# Altium schematic sheet DC_DC.SchDoc
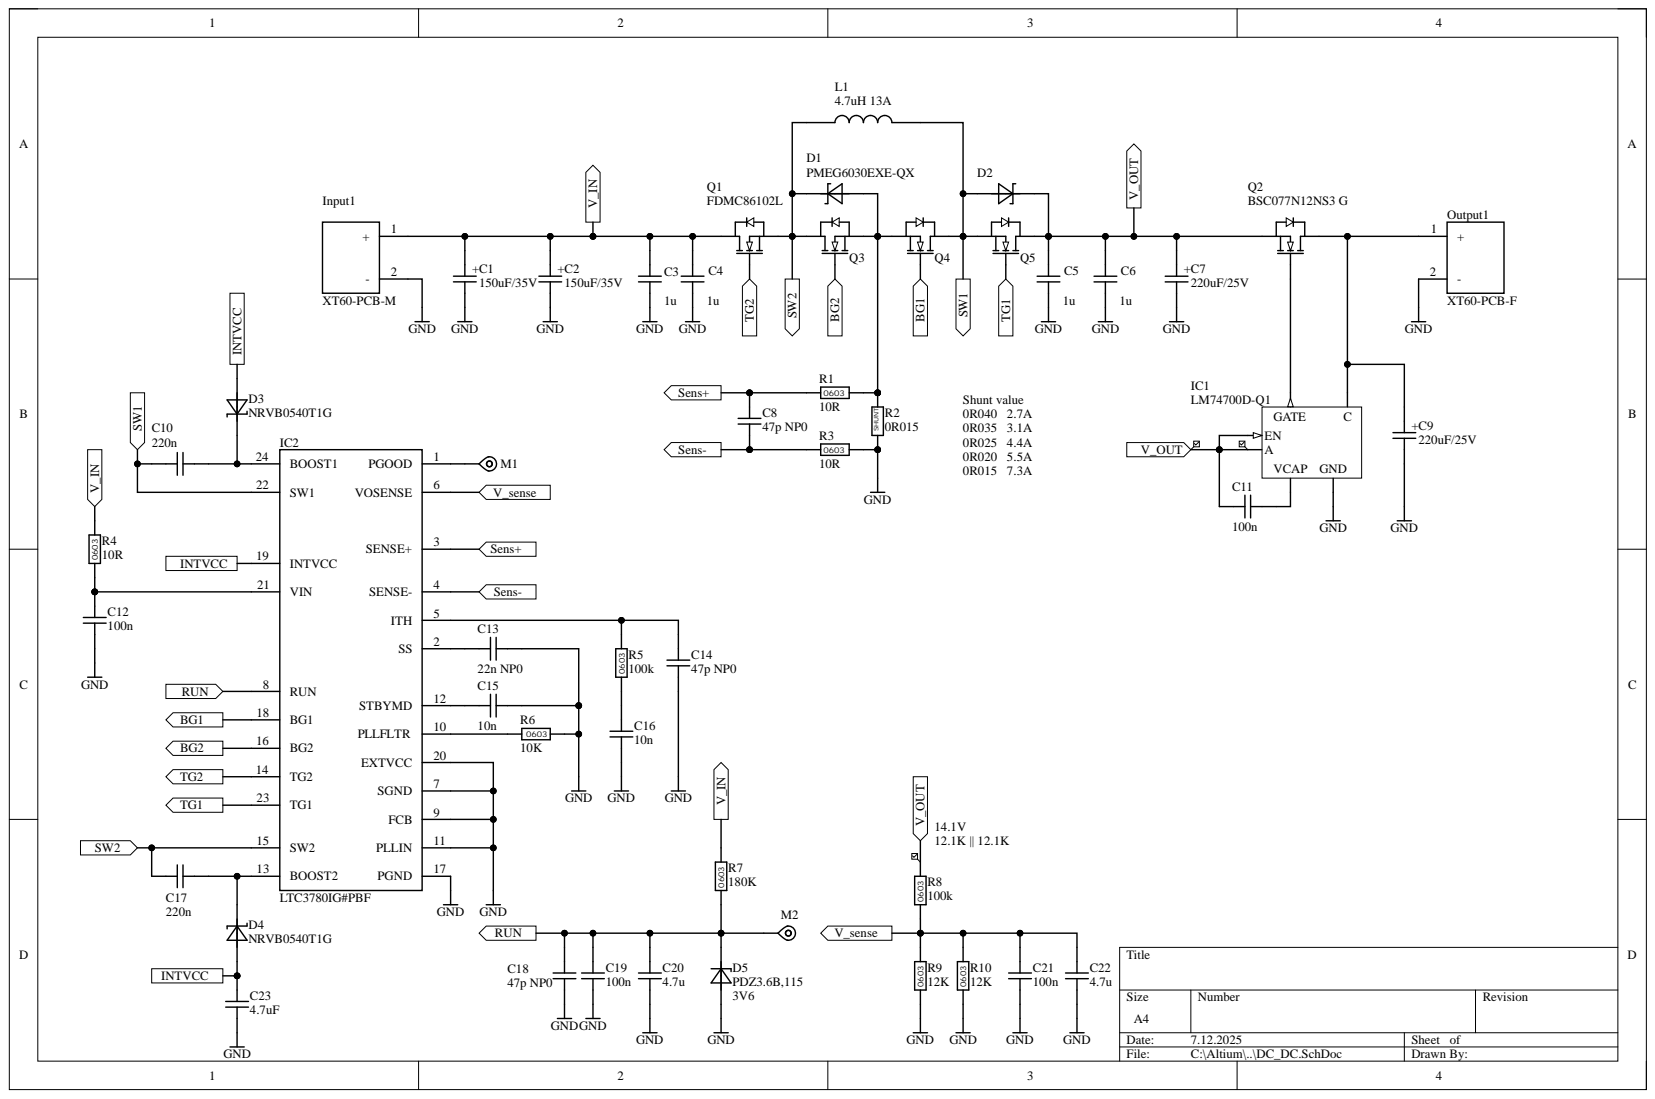
[diagram p0: DC_DC.SchDoc]

## Parts
C1  value 150uF/35V  [PZF8x9]  (hidden comment: 35PZE150M8X9)
C2  value 150uF/35V  [PZF8x9]  (hidden comment: 35PZE150M8X9)
C3  value 1u  [C1206_medium]  (hidden comment: C1206C105K5RACTU)
C4  value 1u  [C1206_medium]  (hidden comment: C1206C105K5RACTU)
C5  value 1u  [C1206_medium]  (hidden comment: C1206C105K5RACTU)
C6  value 1u  [C1206_medium]  (hidden comment: C1206C105K5RACTU)
C7  value 220uF/25V  [PZF8x9]  (hidden comment: 25PZF220M8X9)
C8  value 47p NP0  [C0603]  (hidden comment: GCM1885C2A470JA16J)
C9  value 220uF/25V  [PZF8x9]  (hidden comment: 25PZF220M8X9)
C10  value 220n  [C1206_thin]  (hidden comment: C1206C224J1RACAUTO)
C11  value 100n  [C0603]  (hidden comment: 06035C104K4Z2A)
C12  value 100n  [C1206_thin]  (hidden comment: GRT31C5C2A104JA12L)
C13  value 22n NP0  [C0603]  (hidden comment: AC0603KRX7R9BB223)
C14  value 47p NP0  [C0603]  (hidden comment: GCM1885C2A470JA16J)
C15  value 10n  [C0603]  (hidden comment: C0603X103K1RAC3316)
C16  value 10n  [C0603]  (hidden comment: C0603X103K1RAC3316)
C17  value 220n  [C1206_thin]  (hidden comment: C1206C224J1RACAUTO)
C18  value 47p NP0  [C0603]  (hidden comment: GCM1885C2A470JA16J)
C19  value 100n  [C0603]  (hidden comment: 06035C104K4Z2A)
C20  value 4.7u  [C0603]  (hidden comment: CL10B475KQ8NQNC)
C21  value 100n  [C0603]  (hidden comment: 06035C104K4Z2A)
C22  value 4.7u  [C0603]  (hidden comment: CL10B475KQ8NQNC)
C23  value 4.7uF  [C1206_thick]  (hidden comment: GRM31CC72A475ME11L)
D1  PMEG6030EXE-QX  [SOD123HP]
D2  PMEG6030EXE-QX  [SOD123HP]
D3  NRVB0540T1G  [SOD123HP]
D4  NRVB0540T1G  [SOD123HP]
D5  PDZ3.6B,115  value 3V6  [SOD323]
IC1  LM74700D-Q1  [SOIC127P600X175-8N]
IC2  LTC3780IG#PBF  [SOP65P780X200-24N]
Input1  XT60-PCB-M  [XT60-PCB-M]
L1  value 4.7uH 13A  [WE-HCI]  (hidden comment: 7443551470)
M1  TP  [Pad 1mm SMD]  (value not drawn)  (no BOM)
M2  TP  [Pad 1mm SMD]  (value not drawn)  (no BOM)
Output1  XT60-PCB-F  [XT60-PCB-F]
Q1  FDMC86102L  [PowerPak1212_LFPAK33]
Q2  BSC077N12NS3 G  [Power56_LFPAK]
Q3  FDMC86102L  [PowerPak1212_LFPAK33]
Q4  FDMC86102L  [PowerPak1212_LFPAK33]
Q5  FDMC86102L  [PowerPak1212_LFPAK33]
R1  value 10R  [R0603]  (hidden comment: CR0603-FX-10R0ELF)
R2  value 0R015  [Shunt_2512]  (hidden comment: GMR100HTBFER015)
R3  value 10R  [R0603]  (hidden comment: CR0603-FX-10R0ELF)
R4  value 10R  [R0603]  (hidden comment: CR0603-FX-10R0ELF)
R5  value 100k  [R0603]  (hidden comment: RC0603FR-07100KL)
R6  value 10K  [R0603]  (hidden comment: CRGP0603F10K)
R7  value 180K  [R0603]  (hidden comment: CRGCQ0603F180K)
R8  value 100k  [R0603]  (hidden comment: RC0603FR-07100KL)
R9  value 12K  [R0603]  (hidden comment: CRGCQ0603F12K)
R10  value 12K  [R0603]  (hidden comment: CRGCQ0603F12K)

## Ports
BG1, BG2, INTVCC, RUN, Sens+, Sens-, SW1, SW2, TG1, TG2, V_IN, V_OUT, V_sense

## Nets
GND: C1.2 C2.2 C3.1 C4.1 C5.1 C6.1 C7.2 C9.2 C12.2 C13.1 C14.1 C15.2 C16.1 C18.1 C19.1 C20.2 C21.1 C22.2 C23.2 D5.1 IC1.1 IC2.7(SGND) IC2.9(FCB) IC2.11(PLLIN) IC2.17(PGND) IC2.20(EXTVCC) Input1.2 Output1.2 R2.1 R3.1 R6.2 R9.2 R10.2
BG1: IC2.18(BG1) Q4.4 ; port BG1
BG2: IC2.16(BG2) Q3.4 ; port BG2
INTVCC: C23.1 D3.1 D4.1 IC2.19(INTVCC) ; port INTVCC
RUN: C18.2 C19.2 C20.1 D5.2 IC2.8(RUN) M2.1 R7.2 ; port RUN
SW1: C10.2 D2.1 IC2.22(SW1) L1.1 Q4.5 Q5.1, 2, 3 ; port SW1
SW2: C17.2 D1.2 IC2.15(SW2) L1.2 Q1.1, 2, 3 Q3.5 ; port SW2
TG1: IC2.23(TG1) Q5.4 ; port TG1
TG2: IC2.14(TG2) Q1.4 ; port TG2
V_IN: C1.1 C2.1 C3.2 C4.2 Input1.1 Q1.5 R4.1 R7.1 ; port V_IN
V_OUT: C5.2 C6.2 C7.1 C11.2 D2.2 IC1.2(EN) IC1.5(A) Q2.1, 2, 3 Q5.5 R8.1 ; port V_OUT
V_sense: C21.2 C22.1 IC2.6(VOSENSE) R8.2 R9.1 R10.1 ; port V_sense
NetC8_1: C8.1 IC2.4(SENSE-) R3.2
NetC8_2: C8.2 IC2.3(SENSE+) R1.2
NetC9_1: C9.1 IC1.8(C) Output1.1 Q2.5
NetC10_1: C10.1 D3.2 IC2.24(BOOST1)
NetC11_1: C11.1 IC1.4
NetC12_1: C12.1 IC2.21(VIN) R4.2
NetC13_2: C13.2 IC2.2(SS)
NetC14_2: C14.2 IC2.5(ITH) R5.1
NetC15_1: C15.1 IC2.12(STBYMD)
NetC16_2: C16.2 R5.2
NetC17_1: C17.1 D4.2 IC2.13(BOOST2)
NetD1_1: D1.1 Q3.1, 2, 3 Q4.1, 2, 3 R1.1 R2.2
NetIC1_6: IC1.6 Q2.4
NetIC2_1: IC2.1(PGOOD) M1.1
NetIC2_10: IC2.10(PLLFLTR) R6.1

## Library data of the parts
C1 C2 = 35PZE150M8X9: High temp hybrid capacitor -55/125C  150uF/35V; Main: Capacitors; SMD/THD: THT; Category: Capacitors THT; Component Kind: Standard; Component Type: Capacitors; Library Name: CapRes.IntLib; Status: A; Supplier 1: Mouser; Supplier 2: Digi-Key; Supplier Part Number 1: 232-35PZE150M8X9; Supplier Part Number 2: 35PZE150M8X9-ND
C3-C6 = CC_1206_X7R_105: Ceramic Capacitor X7R 50V; Component Kind: Standard; Library Name: CapRes.IntLib; Supplier 1: Mouser; Supplier 2: Digi-Key; Supplier 3: Farnell; Supplier Part Number 1: 81-GCJ31MR71H105KA2L; Supplier Part Number 2: 490-5810-1-ND; Supplier Part Number 3: 2781416
C7 C9 = 25PZF220M8X9: High temp hybrid capacitor -55/125C  220uF/25V; Main: Capacitors; SMD/THD: THT; Category: Capacitors THT; Component Kind: Standard; Component Type: Capacitors; Library Name: CapRes.IntLib; Status: A; Supplier 1: Mouser; Supplier 2: Digi-Key; Supplier Part Number 1: 232-25PZF220M8X9; Supplier Part Number 2: 25PZF220M8X9-ND
C8 = CC_0603_NP0_470: Ceramic Capacitor NP0 100V; Main: Capacitors; SMD/THD: SMD; Value: 47p NP0; Category: Capacitors CERA SMD; Component Kind: Standard; Component Type: Capacitors; ComponentLink1Description: datasheet; Library Name: CapRes.IntLib; Status: A; Supplier 1: Mouser; Supplier 2: Farnell; Supplier Part Number 1: 81-GCM1885C2A470JA6J; Supplier Part Number 2: 3784365
C10 C17 = CC_1206_X7R_224: Ceramic Capacitor X7R 100V; Component Kind: Standard; Library Name: CapRes.IntLib; Supplier 1: Mouser; Supplier 2: Digi-Key; Supplier Part Number 1: 80-C1206C224J1RAUTO; Supplier Part Number 2: 399-C1206C224J1RACAUTOCT-ND
C11 C19 C21 = CC_0603_X7R_104: Ceramic Capacitor X7R 50V; Manufacturer Part Number: 100n/50V X7R C0603; Main: Capacitors; SMD/THD: SMD; Category: Capacitors CERA SMD; Component Kind: Standard; Component Type: Capacitors; Library Name: CapRes.IntLib; Part Number: 100n/50V X7R C0603; Status: A; Supplier 1: Mouser; Supplier 2: Farnell; Supplier Part Number 1: 581-06035C104K4Z2A; Supplier Part Number 2: 1833843
C12 = CC_1206_NP0_104: Ceramic Capacitor NP0 100V; Component Kind: Standard; Library Name: CapRes.IntLib; Supplier 1: Mouser; Supplier 2: Digi-Key; Supplier Part Number 1: 81-GRT31C5C2A104JA2L; Supplier Part Number 2: 490-GRT31C5C2A104JA12LDKR-ND
C13 = CC_0603_X7R_223: Ceramic Capacitor NP0 50V; Manufacturer Part Number: 22n/50V X7R C0603; Main: Capacitors; SMD/THD: SMD; Category: Capacitors CERA SMD; Component Kind: Standard; Component Type: Capacitors; Library Name: CapRes.IntLib; Part Number: 22n/50V X7R C0603; Status: A; Supplier 1: Mouser; Supplier 2: Farnell; Supplier 3: Digi-Key; Supplier Part Number 1: 603-AC603KRX7R9BB223; Supplier Part Number 2: 3368998; Supplier Part Number 3: 311-3119-6-ND
C14 C18 = CC_0603_NP0_470: Ceramic Capacitor NP0 100V; Main: Capacitors; SMD/THD: SMD; Category: Capacitors CERA SMD; Component Kind: Standard; Component Type: Capacitors; ComponentLink1Description: datasheet; Library Name: CapRes.IntLib; Status: A; Supplier 1: Mouser; Supplier 2: Farnell; Supplier Part Number 1: 81-GCM1885C2A470JA6J; Supplier Part Number 2: 3784365
C15 C16 = CC_0603_X7R_103: Ceramic Capacitor X7R 100V; Part Number: 10n/100V X7R C0603; Main: Capacitors; SMD/THD: SMD; Category: Capacitors CERA SMD; Component Kind: Standard; Component Type: Capacitors; Library Name: CapRes.IntLib; Status: A; Supplier 1: Mouser; Supplier 2: Digi-Key; Supplier 3: Farnell; Supplier 4: TME; Supplier Part Number 1: 80-C0603X103K1R3316; Supplier Part Number 2: 399-C0603X103K1RAC3316CT-ND; Supplier Part Number 3: 2666889; Supplier Part Number 4: C0603X103K1RAC3316
C20 C22 = CC_0603_X7R_475: Ceramic Capacitor X7R 6.3V; Main: Capacitors; SMD/THD: SMD; Category: Capacitors CERA SMD; Component Kind: Standard; Component Type: Capacitors; ComponentLink1Description: datasheet; Library Name: CapRes.IntLib; Status: A; Supplier 1: Mouser; Supplier Part Number 1: 187-CL10B475KQ8NQNC
C23 = CC_1206_X7S_475: Ceramic Capacitor X7S 100V; Component Kind: Standard; Library Name: CapRes.IntLib; Supplier 1: Mouser; Supplier 2: Digi-Key; Supplier Part Number 1: 81-GRM31CC72A475ME11; Supplier Part Number 2: 490-18621-6-ND
D1 D2 = PMEG6030EXE-QX: Schottky Diode 60V, 3A; Main: Diodes; SMD/THD: SMD; Category: Schottky; Component Kind: Standard; Library Name: Semi.IntLib; Status: A; Supplier 1: Digikey; Supplier 2: Mouser; Supplier Part Number 1: 1727-PMEG6030EXE-QXCT-ND; Supplier Part Number 2: 771-PMEG6030EXE-Q; Value: PMEG6030EXE
D3 D4 = NRVB0540T1G: Schottky Diode 40V, 500mA; Main: Diodes; SMD/THD: SMD; Category: Schottky; Component Kind: Standard; Library Name: Semi.IntLib; Status: A; Supplier 1: Mouser; Supplier 2: Farnell; Supplier Part Number 1: 863-NRVB0540T1G; Supplier Part Number 2: 2723913; Value: NRVB0540T1G
D5 = PDZ3.6B,115: Zener Diode 3.6V, 400mW; Main: Diodes; SMD/THD: SMD; Category: Zener; Component Kind: Standard; Library Name: Semi.IntLib; Status: A; Supplier 1: Mouser; Supplier 2: TME; Supplier Part Number 1: 771-PDZ36B8115; Supplier Part Number 2: PDZ3.6B.115
IC1 = LM74700D-Q1: Reverse Battery Protection Ideal Diode Controller; Component Kind: Standard; Library Name: Semi.IntLib
IC2 = LTC3780IG#PBF: DC/DC Buck-Boost; Manufacturer_Name: Analog Devices; Component Kind: Standard; Height: 2mm; Library Name: Semi.IntLib; Manufacturer_Part_Number: LTC3780IG#PBF; Supplier 1: Mouser; Supplier 2: TME; Supplier Part Number 1: 584-LTC3780IG#PBF; Supplier Part Number 2: LTC3780IGPBF
Input1 = XT60-PCB-M: XT60 Connector; Manufacturer_Name: Amass; Manufacturer_Part_Number: XT30PB; Component Kind: Standard; Datasheet Link: https://www.tme.eu/Document/4acc913878197f8c2e30d4b8cdc47230/XT30UPB%20SPEC.pdf; Height: 10.7mm; Library Name: CapRes.IntLib
L1 = WE-HCI High Current 4.7uH-13A: WE-HCI SMT High Current Inductor 4.7uH 20% 100kHz Ferrite 13A SMD T/R   Frequency - Self Resonant 30MHz; Component Kind: Standard; Library Name: CapRes.IntLib; Supplier 1: Digikey; Supplier 2: Mouser; Supplier Part Number 1: 732-7443551470CT-ND; Supplier Part Number 2: 710-7443551470
M1 M2 = TP_PCB: Test Point; Main: Mechanical; Category: Pads_Pins_Measure_Points; Component Type: Mechanical; Library Name: CapRes.IntLib; Stock number: --------
Output1 = XT60-PCB-F: XT60 Connector; Manufacturer_Name: Amass; Manufacturer_Part_Number: XT30PB; Component Kind: Standard; Datasheet Link: https://www.tme.eu/Document/4acc913878197f8c2e30d4b8cdc47230/XT30UPB%20SPEC.pdf; Height: 10.7mm; Library Name: CapRes.IntLib
Q1 Q3-Q5 = FDMC86102L: Single N-Channel 100V, 18A, 23mOhm; Manufacturer: ON Semiconductor / Fairchild; Main: Transistors; SMD/THD: SMD; Category: N-MOS; Component Kind: Standard; Component Type: Transistors; ComponentLink1Description: Datasheet; ComponentLink1URL: http://www.farnell.com/datasheets/1837750.pdf; Library Name: Semi.IntLib; Manufacturer Part Number: FDMC86102L; Part Number: FDMC86102L; Status: A; Supplier 1: Mouser; Supplier 2: Digi-Key; Supplier Part Number 1: 512-FDMC86102L; Supplier Part Number 2: FDMC86102LFSCT-ND; Value: FDMC86102L
Q2 = BSC077N12NS3 G: Single N-Channel 120V, 98A, 7.7mOhm; Manufacturer: Infineon; Main: Transistors; SMD/THD: SMD; Category: N-MOS; Component Kind: Standard; Component Type: Transistors; ComponentLink1Description: Datasheet; ComponentLink1URL: http://datasheet.octopart.com/BSC077N12NS3-G-Infineon-datasheet-62293626.pdf; Library Name: Semi.IntLib; Manufacturer Part Number: BSC077N12NS3G; Part Number: BSC077N12NS3 G; Status: A; Supplier 1: Mouser; Supplier 2: Digi-Key; Supplier Part Number 1: 726-BSC077N12NS3GATM; Supplier Part Number 2: BSC077N12NS3GATMA1CT-ND; Value: BSC077N12NS3 G
R1 R3 R4 = CR_0603_100: Resistor 1%, 0.1W, 75V; Main: Resistors; SMD/THD: SMD; Category: Resistors SMD; Component Kind: Standard; Component Type: Resistors; Library Name: CapRes.IntLib; Status: A; Supplier 1: Mouser; Supplier 2: Farnell; Supplier 3: Digi-Key; Supplier Part Number 1: 652-CR0603FX-10R0ELF; Supplier Part Number 2: 2321756; Supplier Part Number 3: CR0603-FX-10R0ELFDKR-ND
R2 = SHUNT_2512_0R015: Current Sense Resistor 1%, 3W, AEC-Q200; Main: Resistors; SMD/THD: SMD; Category: Resistors SMD; Component Kind: Standard; Component Type: Resistors; Library Name: CapRes.IntLib; Status: A; Supplier 1: Mouser; Supplier 2: Digi-Key; Supplier 3: Farnell; Supplier Part Number 1: 755-GMR100HTBFER015; Supplier Part Number 2: 511-1687-1-ND; Supplier Part Number 3: 2808331
R5 R8 = CR_0603_104: Resistor 1%, 0.1W, 75V; Main: Resistors; SMD/THD: SMD; Category: Resistors SMD; Component Kind: Standard; Component Type: Resistors; Library Name: CapRes.IntLib; Status: A; Supplier 1: Mouser; Supplier 2: Farnell; Supplier 3: TME; Supplier Part Number 1: 603-RC0603FR-07100KL; Supplier Part Number 2: 9238727; Supplier Part Number 3: RC0603FR-07100K
R6 = CR_0603_103: Resistor 1%, 0.1W, 75V; Main: Resistors; SMD/THD: SMD; Category: Resistors SMD; Component Kind: Standard; Component Type: Resistors; Library Name: CapRes.IntLib; Status: A; Supplier 1: Mouser; Supplier 2: Digi-Key; Supplier 3: Farnell; Supplier Part Number 1: 279-CRGP0603F10K; Supplier Part Number 2: A130428CT-ND; Supplier Part Number 3: 2861046
R7 = CR_0603_184: Resistor 1%, 0.1W, 75V; Main: Resistors; SMD/THD: SMD; Category: Resistors SMD; Component Kind: Standard; Component Type: Resistors; Library Name: CapRes.IntLib; Status: A; Supplier 1: Mouser; Supplier 2: Digi-Key; Supplier 3: Farnell; Supplier Part Number 1: 279-CRGCQ0603F180K; Supplier Part Number 2: A129715CT-ND; Supplier Part Number 3: 2861488
R9 R10 = CR_0603_123: Resistor 1%, 0.1W, 75V; Main: Resistors; SMD/THD: SMD; Category: Resistors SMD; Component Kind: Standard; Component Type: Resistors; Library Name: CapRes.IntLib; Status: A; Supplier 1: Mouser; Supplier 2: Digi-Key; Supplier 3: Farnell; Supplier Part Number 1: 279-CRGCQ0603F12K; Supplier Part Number 2: A129701CT-ND; Supplier Part Number 3: 2861474
